# Altium schematic sheet 08 DDR3.SchDoc
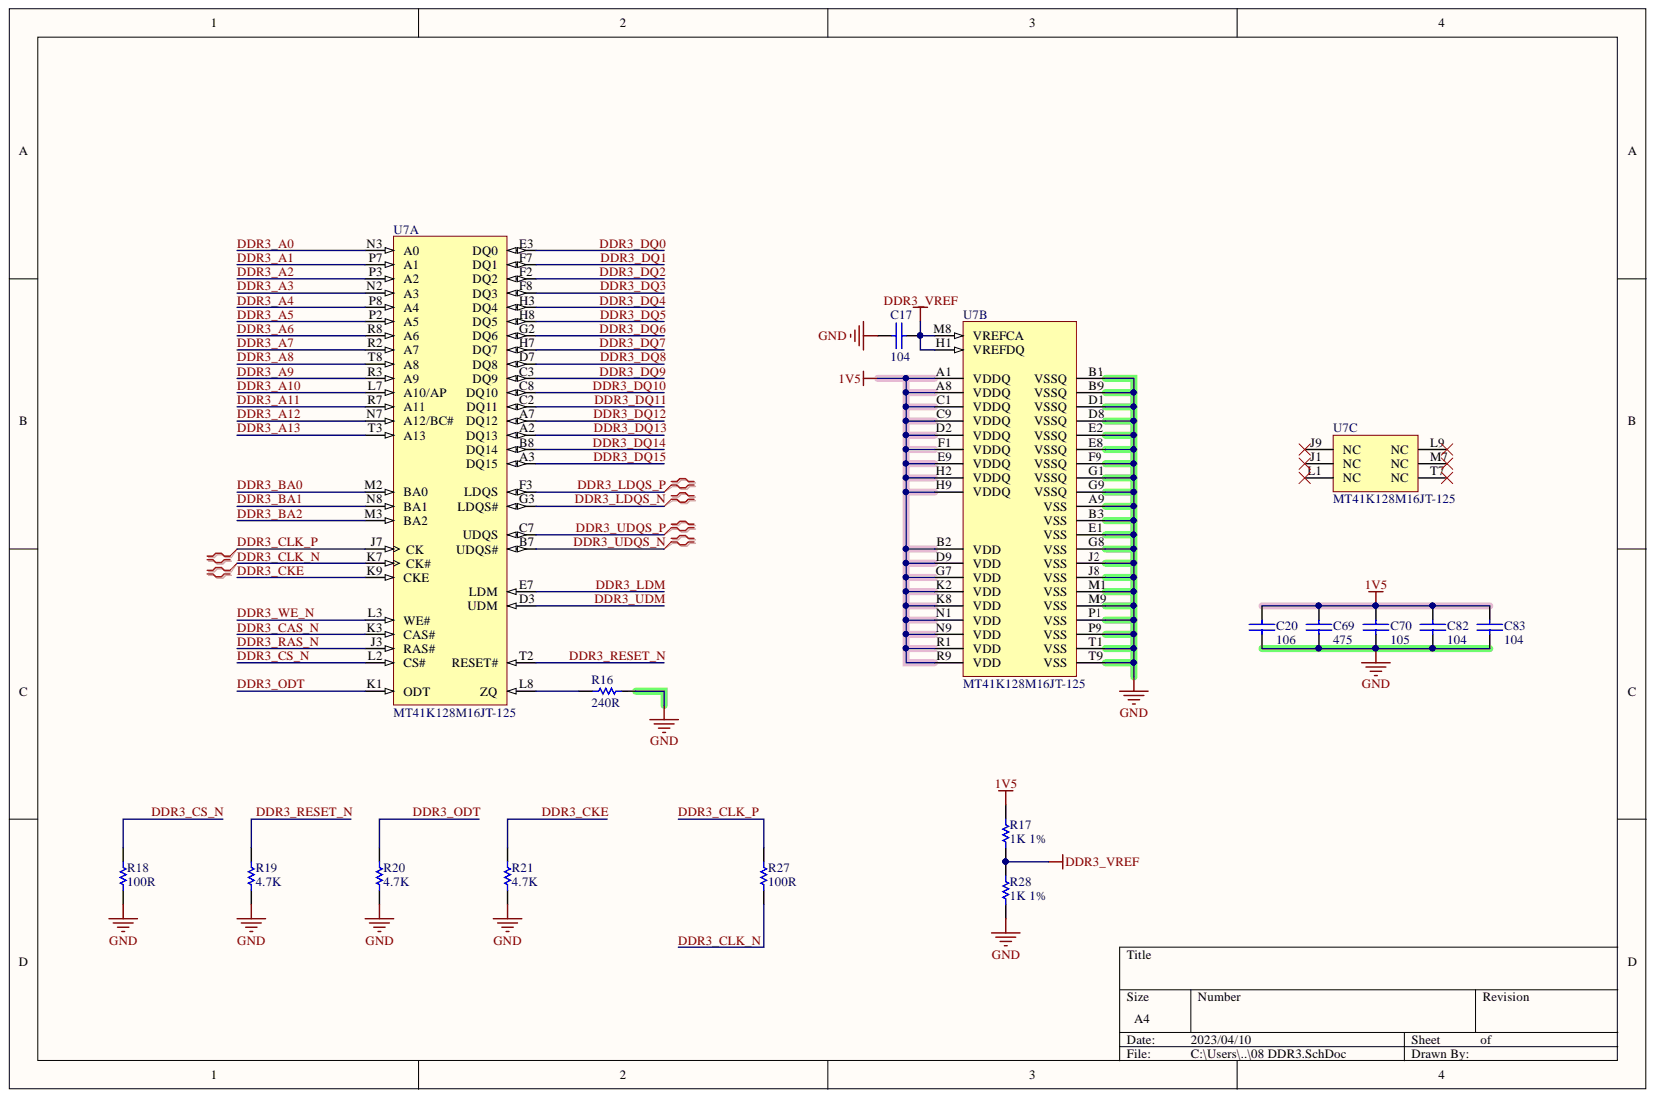
[diagram p0: 08 DDR3.SchDoc]

## Parts
C17  104  [0402C]
C20  106  [0402C]
C69  475  [0402C]
C70  105  [0402C]
C82  104  [0402C]
C83  104  [0402C]
R16  240R  [0402R]
R17  1K 1%  [0402R]
R18  100R  [0402R]
R19  4.7K  [0402R]
R20  4.7K  [0402R]
R21  4.7K  [0402R]
R27  100R  [0402R]
R28  1K 1%  [0402R]
U7  MT41K128M16JT-125  [MICT-JT-96]  (parts A,B,C)

## Nets
1V5: C20.1 C69.1 C70.1 C82.1 C83.1 R17.2 U7.A1(VDDQ) U7.A8(VDDQ) U7.B2(VDD) U7.C1(VDDQ) U7.C9(VDDQ) U7.D2(VDDQ) U7.D9(VDD) U7.E9(VDDQ) U7.F1(VDDQ) U7.G7(VDD) U7.H2(VDDQ) U7.H9(VDDQ) U7.K2(VDD) U7.K8(VDD) U7.N1(VDD) U7.N9(VDD) U7.R1(VDD) U7.R9(VDD)
DDR3_VREF: C17.2 R17.1 R28.2 U7.H1(VREFDQ) U7.M8(VREFCA)
GND: C17.1 C20.2 C69.2 C70.2 C82.2 C83.2 R16.2 R18.1 R19.1 R20.1 R21.1 R28.1 U7.A9(VSS) U7.B1(VSSQ) U7.B3(VSS) U7.B9(VSSQ) U7.D1(VSSQ) U7.D8(VSSQ) U7.E1(VSS) U7.E2(VSSQ) U7.E8(VSSQ) U7.F9(VSSQ) U7.G1(VSSQ) U7.G8(VSS) U7.G9(VSSQ) U7.J2(VSS) U7.J8(VSS) U7.M1(VSS) U7.M9(VSS) U7.P1(VSS) U7.P9(VSS) U7.T1(VSS) U7.T9(VSS)
DDR3_A0: U7.N3(A0)
DDR3_A1: U7.P7(A1)
DDR3_A2: U7.P3(A2)
DDR3_A3: U7.N2(A3)
DDR3_A4: U7.P8(A4)
DDR3_A5: U7.P2(A5)
DDR3_A6: U7.R8(A6)
DDR3_A7: U7.R2(A7)
DDR3_A8: U7.T8(A8)
DDR3_A9: U7.R3(A9)
DDR3_A10: U7.L7(A10/AP)
DDR3_A11: U7.R7(A11)
DDR3_A12: U7.N7(A12/BC#)
DDR3_A13: U7.T3(A13)
DDR3_BA0: U7.M2(BA0)
DDR3_BA1: U7.N8(BA1)
DDR3_BA2: U7.M3(BA2)
DDR3_CAS_N: U7.K3(CAS#)
DDR3_CKE: R21.2 U7.K9(CKE)
DDR3_CLK_N: R27.1 U7.K7(CK#)
DDR3_CLK_P: R27.2 U7.J7(CK)
DDR3_CS_N: R18.2 U7.L2(CS#)
DDR3_DQ0: U7.E3(DQ0)
DDR3_DQ1: U7.F7(DQ1)
DDR3_DQ2: U7.F2(DQ2)
DDR3_DQ3: U7.F8(DQ3)
DDR3_DQ4: U7.H3(DQ4)
DDR3_DQ5: U7.H8(DQ5)
DDR3_DQ6: U7.G2(DQ6)
DDR3_DQ7: U7.H7(DQ7)
DDR3_DQ8: U7.D7(DQ8)
DDR3_DQ9: U7.C3(DQ9)
DDR3_DQ10: U7.C8(DQ10)
DDR3_DQ11: U7.C2(DQ11)
DDR3_DQ12: U7.A7(DQ12)
DDR3_DQ13: U7.A2(DQ13)
DDR3_DQ14: U7.B8(DQ14)
DDR3_DQ15: U7.A3(DQ15)
DDR3_LDM: U7.E7(LDM)
DDR3_LDQS_N: U7.G3(LDQS#)
DDR3_LDQS_P: U7.F3(LDQS)
DDR3_ODT: R20.2 U7.K1(ODT)
DDR3_RAS_N: U7.J3(RAS#)
DDR3_RESET_N: R19.2 U7.T2(RESET#)
DDR3_UDM: U7.D3(UDM)
DDR3_UDQS_N: U7.B7(UDQS#)
DDR3_UDQS_P: U7.C7(UDQS)
DDR3_WE_N: U7.L3(WE#)
NetR16_1: R16.1 U7.L8(ZQ)

Not connected: U7 J1(NC) J9(NC) L1(NC) L9(NC) M7(NC) T7(NC)

## Library data of the parts
C17 C20 C69 C70 C82 C83 = CAP: 无极性电容; Component Kind: Standard; Library Name: 电容.IntLib
R16-R21 R27 R28 = RES: Component Kind: Standard; Library Name: 电阻.IntLib
U7 = MT41K128M16JT-125: 2Gb DDR3-1600 SDRAM, 128Mb x 16, 1.25ns, 1.35V, 0 to 95 degC, 96-Ball FBGA, Pb-Free; Manufacturer: Micron; CL: CL = 11?; Clock Rate: 800 MHz?; Cycle Time: 1.25ns?; Data Rate: DDR3-1600?; Density: 2Gb?; Op. Temp.: 0C to +95C?; Voltage: 1.35V?; Component Kind: Standard; ComponentLink1Description: Manufacturer URL; ComponentLink1URL: http://www.micron.com/; ComponentLink2Description: Datasheet; ComponentLink2URL: http://www.micron.com/~/media/Documents/Products/Data%20Sheet/DRAM/2Gb_1_35V_DDR3L.pdf; ComponentLink3Description: Package Specification; ComponentLink3URL: http://www.micron.com/~/media/Documents/Products/Data%20Sheet/DRAM/2Gb_DDR3_SDRAM.pdf; DatasheetVersion: Rev.G; Depth: 128Mb?; Library Name: Micron Memory DDR3 SDRAM.IntLib; Mounting Technology: Surface Mount; Package: FBGA?; PackageDescription: 96-Ball Fine-Pitch Ball Grid Array, 14 x 8 mm, Pitch 0.8 mm; PackageReference: MICT-JT-96; PackageVersion: Rev.G; PartNumber: MT41K128M16JT-125; Pin Count: 96-ball?; RoHS: Yes?; Width: x16?
